# Altium schematic sheet SmartMushroomV1.SchDoc(SmartMushroomV1)
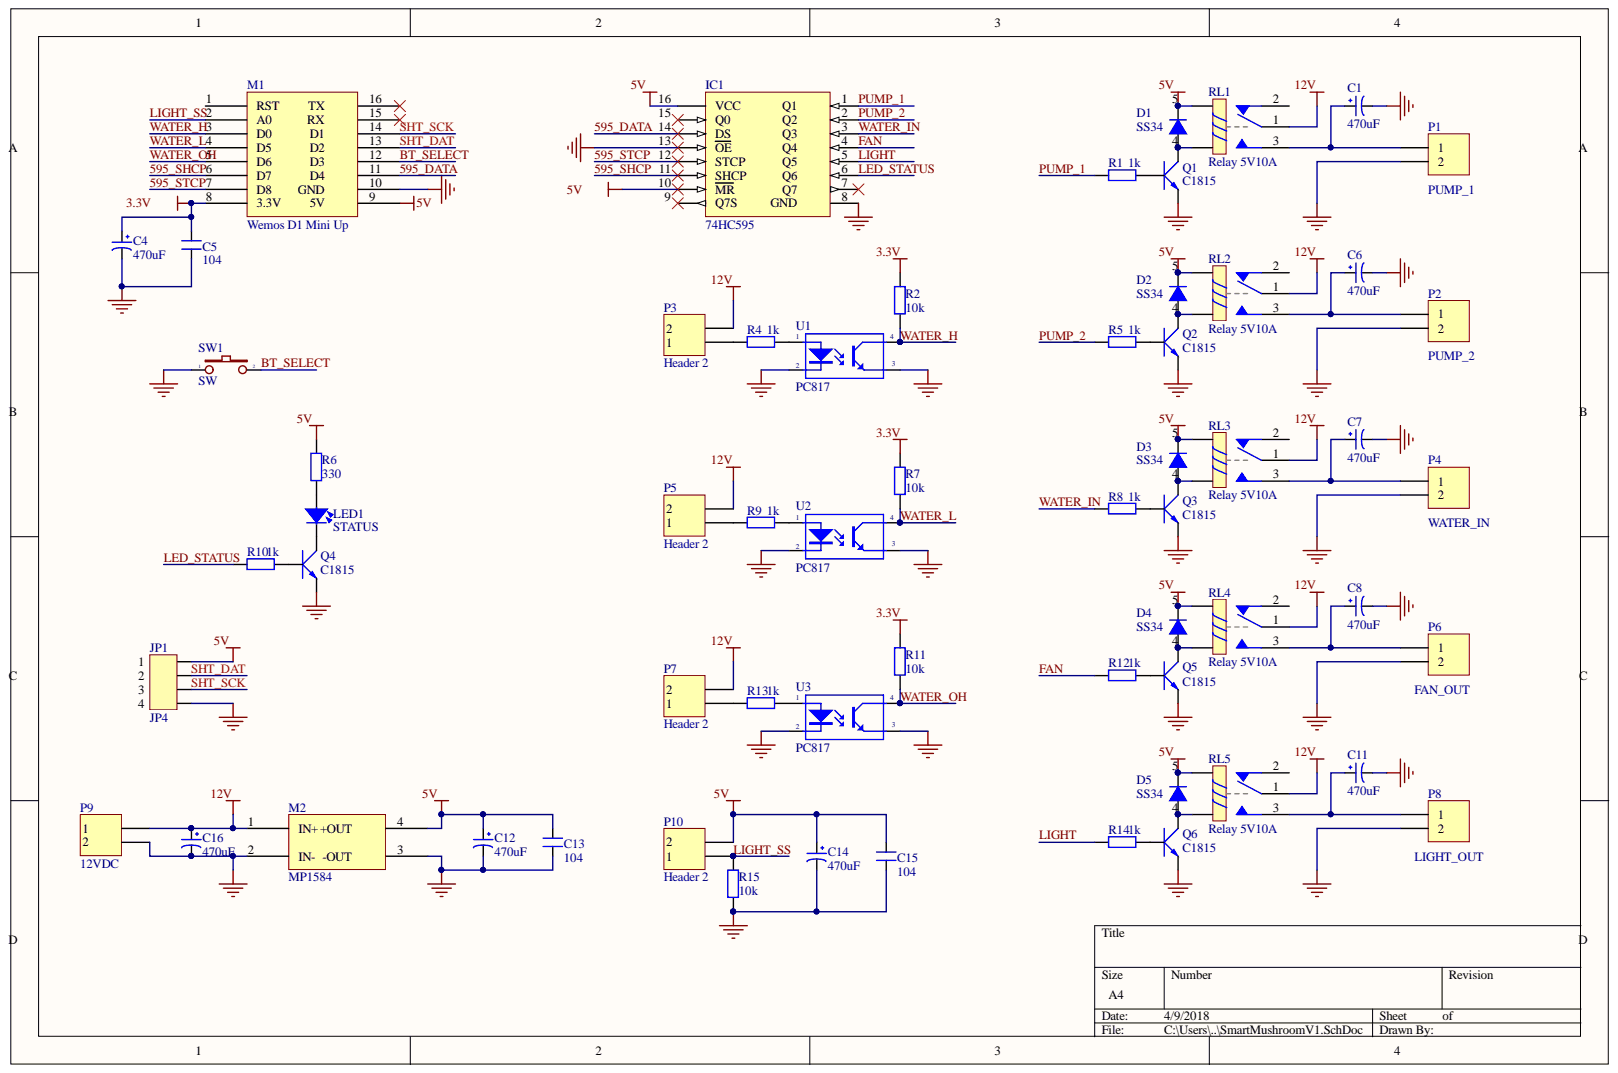
[diagram p0: SmartMushroomV1.SchDoc]

## Parts
C1  470uF  [CP1.5/2.5]
C4  470uF  [CP1.5/2.5]
C5  104  [CAPC2012N_F]
C6  470uF  [CP1.5/2.5]
C7  470uF  [CP1.5/2.5]
C8  470uF  [CP1.5/2.5]
C11  470uF  [CP1.5/2.5]
C12  470uF  [CP1.5/2.5]
C13  104  [CAPC2012N_F]
C14  470uF  [CP1.5/2.5]
C15  104  [CAPC2012N_F]
C16  470uF  [CP1.5/2.5]
D1  SS34  [DO-214AC]
D2  SS34  [DO-214AC]
D3  SS34  [DO-214AC]
D4  SS34  [DO-214AC]
D5  SS34  [DO-214AC]
IC1  74HC595  [DIP16/W0.3]
JP1  JP4  [CON4]
LED1  STATUS  [LED-3mm Red]
M1  Wemos D1 Mini Up  [Wemos D1 Mini Up]
M2  MP1584  [MP1584 Module]
P1  PUMP_1  [HED2 3.81]
P2  PUMP_2  [HED2 3.81]
P3  Header 2  [CON2]
P4  WATER_IN  [HED2 3.81]
P5  Header 2  [CON2]
P6  FAN_OUT  [HED2 3.81]
P7  Header 2  [CON2]
P8  LIGHT_OUT  [HED2 3.81]
P9  12VDC  [HED2 3.81]
P10  Header 2  [CON2]
Q1  C1815  [SOT23-3]
Q2  C1815  [SOT23-3]
Q3  C1815  [SOT23-3]
Q4  C1815  [SOT23-3]
Q5  C1815  [SOT23-3]
Q6  C1815  [SOT23-3]
R1  value 1k  [RESC2012N_F]  (hidden comment: 220)
R2  value 10k  [RESC2012N_F]  (hidden comment: 220)
R4  value 1k  [RESC2012N_F]  (hidden comment: 220)
R5  value 1k  [RESC2012N_F]  (hidden comment: 220)
R6  value 330  [RESC2012N_F]  (hidden comment: 220)
R7  value 10k  [RESC2012N_F]  (hidden comment: 220)
R8  value 1k  [RESC2012N_F]  (hidden comment: 220)
R9  value 1k  [RESC2012N_F]  (hidden comment: 220)
R10  value 1k  [RESC2012N_F]  (hidden comment: 220)
R11  value 10k  [RESC2012N_F]  (hidden comment: 220)
R12  value 1k  [RESC2012N_F]  (hidden comment: 220)
R13  value 1k  [RESC2012N_F]  (hidden comment: 220)
R14  value 1k  [RESC2012N_F]  (hidden comment: 220)
R15  value 10k  [RESC2012N_F]  (hidden comment: 220)
RL1  Relay 5V10A  [RL_SPDT_10A]
RL2  Relay 5V10A  [RL_SPDT_10A]
RL3  Relay 5V10A  [RL_SPDT_10A]
RL4  Relay 5V10A  [RL_SPDT_10A]
RL5  Relay 5V10A  [RL_SPDT_10A]
SW1  SW  [SW2]
U1  PC817  [DIP4/W0.3]
U2  PC817  [DIP4/W0.3]
U3  PC817  [DIP4/W0.3]

## Nets
3.3V: C4.1 C5.2 M1.8(3.3V) R2.1 R7.1 R11.1
5V: C12.1 C13.2 C14.1 C15.2 D1.K D2.K D3.K D4.K D5.K IC1.10(MR) IC1.16(VCC) JP1.1 M1.9 M2.4 P10.2 R6.2 RL1.5 RL2.5 RL3.5 RL4.5 RL5.5
12V: C16.1 M2.1(IN+_+OUT) P3.2 P5.2 P7.2 P9.1 RL1.1 RL2.1 RL3.1 RL4.1 RL5.1
GND: C1.2 C4.2 C5.1 C6.2 C7.2 C8.2 C11.2 C12.2 C13.1 C14.2 C15.1 C16.2 IC1.8 IC1.13(OE) JP1.4 M1.10 M2.2(IN-) M2.3 P1.2 P2.2 P4.2 P6.2 P8.2 P9.2 Q1.2 Q2.2 Q3.2 Q4.2 Q5.2 Q6.2 R15.2 SW1.1 U1.2 U1.3 U2.2 U2.3 U3.2 U3.3
595_DATA: IC1.14(DS) M1.11
595_SHCP: IC1.11(SHCP) M1.6(D7)
595_STCP: IC1.12(STCP) M1.7(D8)
BT_SELECT: M1.12 SW1.2
FAN: IC1.4 R12.1
LED_STATUS: IC1.6 R10.1
LIGHT: IC1.5 R14.1
LIGHT_SS: M1.2(A0) P10.1 R15.1
PUMP_1: IC1.1 R1.1
PUMP_2: IC1.2 R5.1
SHT_DAT: JP1.2 M1.13
SHT_SCK: JP1.3 M1.14
WATER_H: M1.3(D0) R2.2 U1.4
WATER_IN: IC1.3 R8.1
WATER_L: M1.4(D5) R7.2 U2.4
WATER_OH: M1.5(D6) R11.2 U3.4
NetC1_1: C1.1 P1.1 RL1.3
NetC6_1: C6.1 P2.1 RL2.3
NetC7_1: C7.1 P4.1 RL3.3
NetC8_1: C8.1 P6.1 RL4.3
NetC11_1: C11.1 P8.1 RL5.3
NetD1_A: D1.A Q1.3 RL1.4
NetD2_A: D2.A Q2.3 RL2.4
NetD3_A: D3.A Q3.3 RL3.4
NetD4_A: D4.A Q5.3 RL4.4
NetD5_A: D5.A Q6.3 RL5.4
NetLED1_1: LED1.1 R6.1
NetLED1_2: LED1.2 Q4.3
NetP3_1: P3.1 R4.1
NetP5_1: P5.1 R9.1
NetP7_1: P7.1 R13.1
NetQ1_1: Q1.1 R1.2
NetQ2_1: Q2.1 R5.2
NetQ3_1: Q3.1 R8.2
NetQ4_1: Q4.1 R10.2
NetQ5_1: Q5.1 R12.2
NetQ6_1: Q6.1 R14.2
NetR4_2: R4.2 U1.1
NetR9_2: R9.2 U2.1
NetR13_2: R13.2 U3.1

Not connected: IC1 7 9(Q7S) 15(Q0); M1 1(RST) 15 16; RL1 2; RL2 2; RL3 2; RL4 2; RL5 2

## Library data of the parts
C1 C4 C6-C8 C11 C12 C14 C16 = CP3: Cap 100uF/35V - 470uF/16V; Description 1: 1000uF/6,3V - 470uF/16V - 330uF - 25V; Description 2: 220uF/35V - 100uF/50V - 63V - 4,7uF/200V; Description 3: 22uF => 33uF100V - 3,3uF => 4,7uF/250V; Description 4: 2,2uF =>3,3uF/350 - 2,2uF/400V - 1uF/450V; Value: 100uF/35V/50V; Component Kind: Standard; Library Name: DuyLuan.SchLib
C5 C13 C15 = CN1: Cap np  1pF - 472pF; Value: 100pF; Component Kind: Standard; Library Name: DuyLuan.SchLib
D1-D5 = D1A: Diode 1A; Value: 1N4007; Component Kind: Standard; Library Name: VioletofSun.SchLib
IC1 = 74HC595: 8-Bit Parallel In/Serial Out Shift Register; Component Kind: Standard; PCB3D: DIP16; Library Name: DuyLuan.SchLib
JP1 = Con4: Connector 4; Component Kind: Standard; Value: Connector 4; Library Name: VietSon.SchLib
LED1 = LED_3: LED Single colour Green and Red; Value: LED 3 ly Single Colour; Component Kind: Standard; Library Name: VietSon.SchLib
M1 = Wemos D1 Mini Up: Wemos D1 Mini Wiphi is top Up; Component Kind: Standard; Library Name: DuyLuan.SchLib
M2 = MP1584 Module: Component Kind: Standard; Library Name: DuyLuan.SchLib
P1-P10 = Header 2: Header, 2-Pin; Component Kind: Standard; LatestRevisionDate: 17-Jul-2002; LatestRevisionNote: Re-released for DXP Platform.; Library Name: NBBLib 14-03-2012.IntLib; Signal Integrity: Connector; Publisher: Altium Limited
Q1-Q6 = C1815: NPN 600mW, ECB; : C2383; : C828; Component Kind: Standard; Value: C1815; Library Name: VioletofSun.SchLib
R1 R2 R4-R15 = Res 1/4 W: Resistor; Component Kind: Standard; LatestRevisionDate: 17-Jul-2002; LatestRevisionNote: Re-released for DXP Platform.; Library Name: NBBLib 14-03-2012.IntLib; PackageReference: AXIAL-0.4; Published: 8-Jun-2000; Signal Integrity: Res; Simulation: RESISTOR; Publisher: Altium Limited
RL1-RL5 = Relay 5: Relay SPDT,10A - 12VDC 5 Pin; Value: Relay SPDT, 10A, 12V; : Relay SPDT, 10A, 24V; Component Kind: Standard; Library Name: LIBRARY.IntLib
SW1 = SW2: Switch button 2 pin; Value: SW chip 2 pin; Component Kind: Standard; Library Name: DuyLuan.SchLib
U1-U3 = PC817: Single Opto; Component Kind: Standard; Value: PC817; Library Name: DuyLuan.SchLib
